# Altium schematic sheet Carte_Controle_Bras.SchDoc
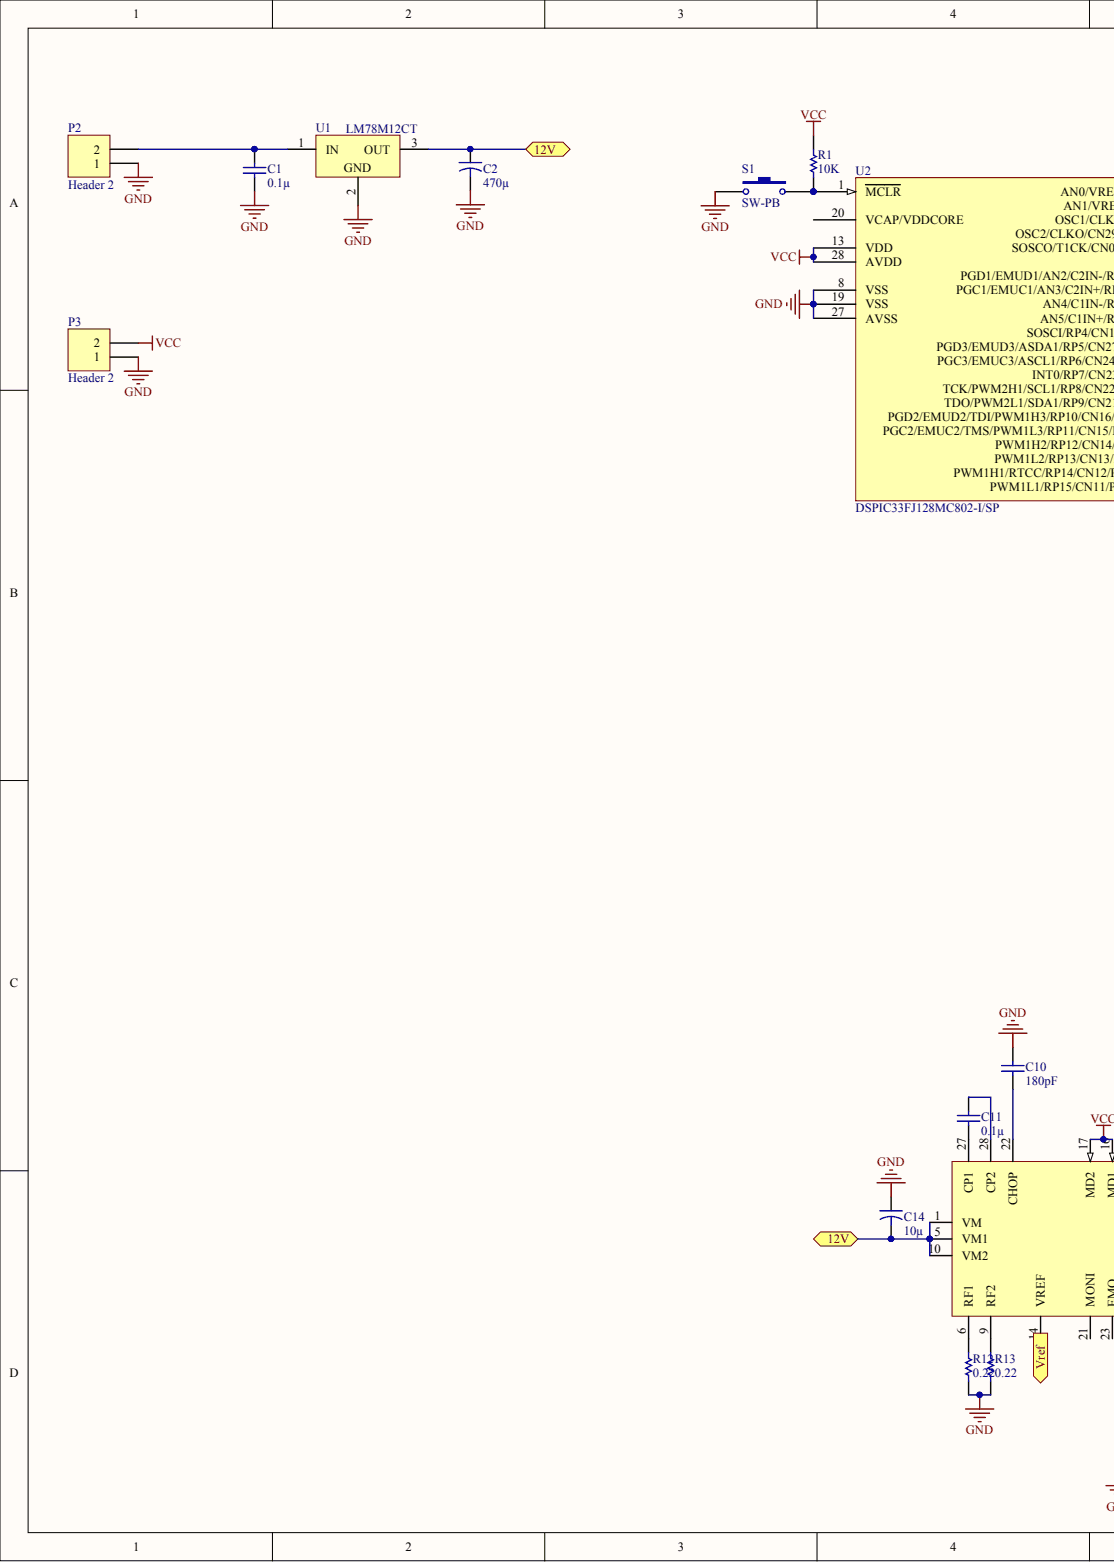
[diagram p0: Carte_Controle_Bras.SchDoc, left]
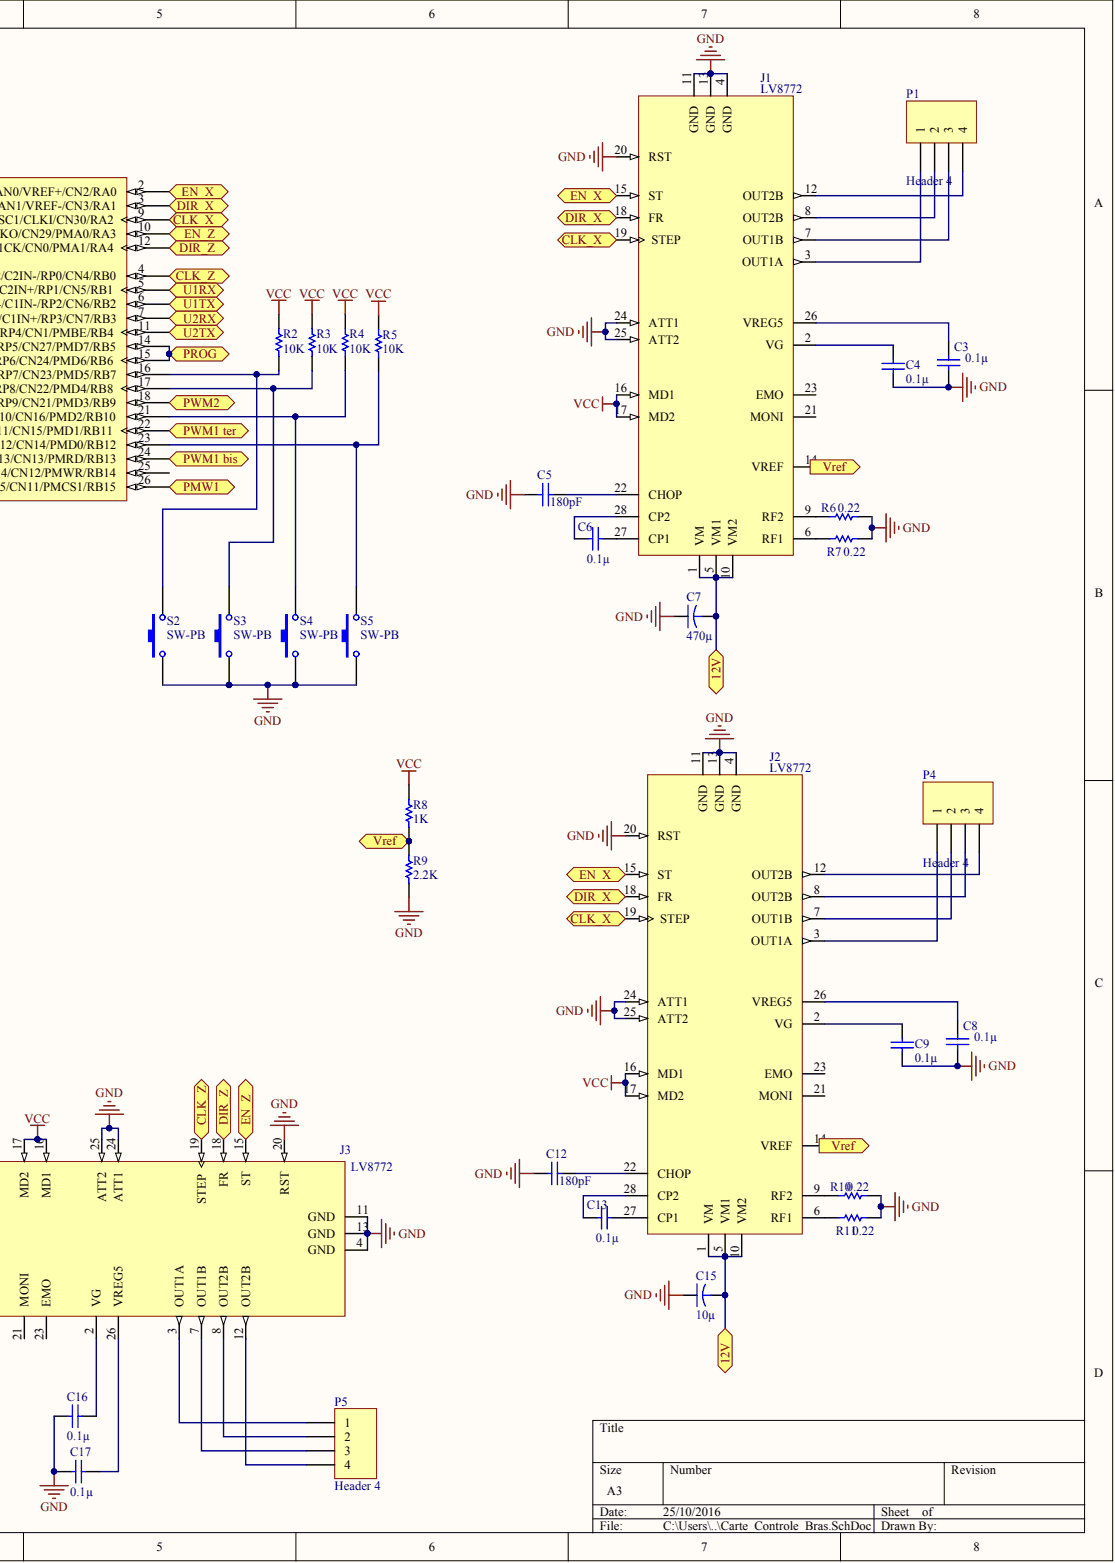
[diagram p1: Carte_Controle_Bras.SchDoc, right]

## Parts
C1  value 0.1µ  [RAD-0.3]  (hidden comment: Cap)
C2  value 470µ  [CAPR5-4X5]  (hidden comment: Cap2)
C3  value 0.1µ  [RAD-0.3]  (hidden comment: Cap)
C4  value 0.1µ  [RAD-0.3]  (hidden comment: Cap)
C5  value 180pF  [RAD-0.3]  (hidden comment: Cap)
C6  value 0.1µ  [RAD-0.3]  (hidden comment: Cap)
C7  value 470µ  [CAPR5-4X5]  (hidden comment: Cap2)
C8  value 0.1µ  [RAD-0.3]  (hidden comment: Cap)
C9  value 0.1µ  [RAD-0.3]  (hidden comment: Cap)
C10  value 180pF  [RAD-0.3]  (hidden comment: Cap)
C11  value 0.1µ  [RAD-0.3]  (hidden comment: Cap)
C12  value 180pF  [RAD-0.3]  (hidden comment: Cap)
C13  value 0.1µ  [RAD-0.3]  (hidden comment: Cap)
C14  value 10µ  [CAPR5-4X5]  (hidden comment: Cap2)
C15  value 10µ  [CAPR5-4X5]  (hidden comment: Cap2)
C16  value 0.1µ  [RAD-0.3]  (hidden comment: Cap)
C17  value 0.1µ  [RAD-0.3]  (hidden comment: Cap)
J1  LV8772
J2  LV8772
J3  LV8772
P1  Header 4  [HDR1X4]
P2  Header 2  [HDR1X2]
P3  Header 2  [HDR1X2]
P4  Header 4  [HDR1X4]
P5  Header 4  [HDR1X4]
R1  value 10K  [AXIAL-0.3]  (hidden comment: Res1)
R2  value 10K  [AXIAL-0.3]  (hidden comment: Res1)
R3  value 10K  [AXIAL-0.3]  (hidden comment: Res1)
R4  value 10K  [AXIAL-0.3]  (hidden comment: Res1)
R5  value 10K  [AXIAL-0.3]  (hidden comment: Res1)
R6  value 0.22  [AXIAL-0.3]  (hidden comment: Res1)
R7  value 0.22  [AXIAL-0.3]  (hidden comment: Res1)
R8  value 1K  [AXIAL-0.3]  (hidden comment: Res1)
R9  value 2.2K  [AXIAL-0.3]  (hidden comment: Res1)
R10  value 0.22  [AXIAL-0.3]  (hidden comment: Res1)
R11  value 0.22  [AXIAL-0.3]  (hidden comment: Res1)
R12  value 0.22  [AXIAL-0.3]  (hidden comment: Res1)
R13  value 0.22  [AXIAL-0.3]  (hidden comment: Res1)
S1  SW-PB  [SPST-2]
S2  SW-PB  [SPST-2]
S3  SW-PB  [SPST-2]
S4  SW-PB  [SPST-2]
S5  SW-PB  [SPST-2]
U1  LM78M12CT  [T03B]
U2  DSPIC33FJ128MC802-I/SP  [SPDIP300-SP28]

## Ports
12V, CLK_X, CLK_Z, DIR_X, DIR_Z, EN_X, EN_Z, PMW1, PROG, PWM1 BIS, PWM1 TER, PWM2, U1RX, U1TX, U2RX, U2TX, VREF

## Nets
GND: C1.1 C2.2 C3.2 C4.1 C5.2 C7.1 C8.2 C9.1 C10.2 C12.2 C14.1 C15.1 C16.1 C17.2 J1.4(GND) J1.11(GND) J1.13(GND) J1.20(RST) J1.24(ATT1) J1.25(ATT2) J2.4(GND) J2.11(GND) J2.13(GND) J2.20(RST) J2.24(ATT1) J2.25(ATT2) J3.4(GND) J3.11(GND) J3.13(GND) J3.20(RST) J3.24(ATT1) J3.25(ATT2) P2.1 P3.1 R6.1 R7.1 R9.2 R10.1 R11.1 R12.1 R13.1 S1.1 S2.1 S3.1 S4.1 S5.1 U1.2 U2.8(VSS) U2.19(VSS) U2.27(AVSS)
VCC: J1.16(MD1) J1.17(MD2) J2.16(MD1) J2.17(MD2) J3.16(MD1) J3.17(MD2) P3.2 R1.1 R2.1 R3.1 R4.1 R5.1 R8.1 U2.13(VDD) U2.28(AVDD)
12V: C2.1 C7.2 C14.2 C15.2 J1.1(VM) J1.5(VM1) J1.10(VM2) J2.1(VM) J2.5(VM1) J2.10(VM2) J3.1(VM) J3.5(VM1) J3.10(VM2) U1.3 ; port 12V
CLK_X: J1.19(STEP) J2.19(STEP) U2.9(OSC1/CLKI/CN30/RA2) ; port CLK_X
CLK_Z: J3.19(STEP) U2.4(PGD1/EMUD1/AN2/C2IN-/RP0/CN4/RB0) ; port CLK_Z
DIR_X: J1.18(FR) J2.18(FR) U2.3(AN1/VREF-/CN3/RA1) ; port DIR_X
DIR_Z: J3.18(FR) U2.12(SOSCO/T1CK/CN0/PMA1/RA4) ; port DIR_Z
EN_X: J1.15(ST) J2.15(ST) U2.2(AN0/VREF+/CN2/RA0) ; port EN_X
EN_Z: J3.15(ST) U2.10(OSC2/CLKO/CN29/PMA0/RA3) ; port EN_Z
PMW1: U2.26(PWM1L1/RP15/CN11/PMCS1/RB15) ; port PMW1
PROG: U2.14(PGD3/EMUD3/ASDA1/RP5/CN27/PMD7/RB5) U2.15(PGC3/EMUC3/ASCL1/RP6/CN24/PMD6/RB6) ; port PROG
PWM2: U2.18(TDO/PWM2L1/SDA1/RP9/CN21/PMD3/RB9) ; port PWM2
U1RX: U2.5(PGC1/EMUC1/AN3/C2IN+/RP1/CN5/RB1) ; port U1RX
U1TX: U2.6(AN4/C1IN-/RP2/CN6/RB2) ; port U1TX
U2RX: U2.7(AN5/C1IN+/RP3/CN7/RB3) ; port U2RX
U2TX: U2.11(SOSCI/RP4/CN1/PMBE/RB4) ; port U2TX
NetC1_2: C1.2 P2.2 U1.1
NetC3_1: C3.1 J1.26(VREG5)
NetC4_2: C4.2 J1.2(VG)
NetC5_1: C5.1 J1.22(CHOP)
NetC6_1: C6.1 J1.27(CP1)
NetC6_2: C6.2 J1.28(CP2)
NetC8_1: C8.1 J2.26(VREG5)
NetC9_2: C9.2 J2.2(VG)
NetC10_1: C10.1 J3.22(CHOP)
NetC11_1: C11.1 J3.27(CP1)
NetC11_2: C11.2 J3.28(CP2)
NetC12_1: C12.1 J2.22(CHOP)
NetC13_1: C13.1 J2.27(CP1)
NetC13_2: C13.2 J2.28(CP2)
NetC16_2: C16.2 J3.2(VG)
NetC17_1: C17.1 J3.26(VREG5)
NetJ1_3: J1.3(OUT1A) P1.1
NetJ1_6: J1.6(RF1) R7.2
NetJ1_7: J1.7(OUT1B) P1.3
NetJ1_8: J1.8(OUT2B) P1.2
NetJ1_9: J1.9(RF2) R6.2
NetJ1_12: J1.12(OUT2B) P1.4
NetJ1_14: J1.14(VREF) J2.14(VREF) J3.14(VREF) R8.2 R9.1 ; port VREF
NetJ2_3: J2.3(OUT1A) P4.1
NetJ2_6: J2.6(RF1) R11.2
NetJ2_7: J2.7(OUT1B) P4.2
NetJ2_8: J2.8(OUT2B) P4.3
NetJ2_9: J2.9(RF2) R10.2
NetJ2_12: J2.12(OUT2B) P4.4
NetJ3_3: J3.3(OUT1A) P5.1
NetJ3_6: J3.6(RF1) R12.2
NetJ3_7: J3.7(OUT1B) P5.3
NetJ3_8: J3.8(OUT2B) P5.2
NetJ3_9: J3.9(RF2) R13.2
NetJ3_12: J3.12(OUT2B) P5.4
NetR1_2: R1.2 S1.2 U2.1(MCLR)
NetR2_2: R2.2 S2.2 U2.16(INT0/RP7/CN23/PMD5/RB7)
NetR3_2: R3.2 S3.2 U2.17(TCK/PWM2H1/SCL1/RP8/CN22/PMD4/RB8)
NetR4_2: R4.2 S4.2 U2.21(PGD2/EMUD2/TDI/PWM1H3/RP10/CN16/PMD2/RB10)
NetR5_2: R5.2 S5.2 U2.23(PWM1H2/RP12/CN14/PMD0/RB12)
NetU2_22: U2.22(PGC2/EMUC2/TMS/PWM1L3/RP11/CN15/PMD1/RB11) ; port PWM1 TER
NetU2_24: U2.24(PWM1L2/RP13/CN13/PMRD/RB13) ; port PWM1 BIS

Not connected: J1 21(MONI) 23(EMO); J2 21(MONI) 23(EMO); J3 21(MONI) 23(EMO); U2 20(VCAP/VDDCORE) 25(PWM1H1/RTCC/RP14/CN12/PMWR/RB14)

## Library data of the parts
C1 C3-C6 C8-C13 C16 C17 = Cap: Capacitor; Component Kind: Standard; LatestRevisionDate: 17-Jul-2002; LatestRevisionNote: Re-released for DXP Platform.; Library Name: Miscellaneous Devices.IntLib; PackageReference: RAD-0.3; Published: 8-Jun-2000; Signal Integrity: Cap; Simulation: CAP; Publisher: Altium Limited
C2 C7 C14 C15 = Cap2: Capacitor; Component Kind: Standard; Library Name: Miscellaneous Devices.IntLib; PackageReference: CAPR5-4X5; Published: 23-Sep-2002; Signal Integrity: Cap; Simulation: CAP; Publisher: Altium Limited
P1 P4 P5 = Header 4: Header, 4-Pin; Component Kind: Standard; LatestRevisionDate: 17-Jul-2002; LatestRevisionNote: Re-released for DXP Platform.; Library Name: Miscellaneous Connectors.IntLib; Signal Integrity: Connector; Publisher: Altium Limited
P2 P3 = Header 2: Header, 2-Pin; Component Kind: Standard; LatestRevisionDate: 17-Jul-2002; LatestRevisionNote: Re-released for DXP Platform.; Library Name: Miscellaneous Connectors.IntLib; Signal Integrity: Connector; Publisher: Altium Limited
R1-R13 = Res1: Resistor; Component Kind: Standard; LatestRevisionDate: 17-Jul-2002; LatestRevisionNote: Re-released for DXP Platform.; Library Name: Miscellaneous Devices.IntLib; PackageReference: AXIAL-0.3; Published: 8-Jun-2000; Signal Integrity: Res; Simulation: RESISTOR; Publisher: Altium Limited
S1-S5 = SW-PB: Switch; Component Kind: Standard; LatestRevisionDate: 17-Jul-2002; LatestRevisionNote: Re-released for DXP Platform.; Library Name: Miscellaneous Devices.IntLib; PackageDescription: Switch; 2 Leads; PackageReference: SPST-2; Published: 24-Mar-1999; Publisher: Altium Limited
U1 = LM78M12CT: 3-Terminal Positive Voltage Regulators, 3-pin TO-220; Manufacturer: National Semiconductor; CompType: LDO IC; PackageTopMarking: NSUZXYTTE#*LM341T-12*LM78M12CT; Component Kind: Standard; ComponentLink1Description: LM78M12 Datasheet; ComponentLink1URL: http://www.national.com/ds/LM/LM78M12.pdf; ComponentLink2Description: LM78M12 Web Page; ComponentLink2URL: http://www.national.com/pf/LM/LM78M12.html; ComponentLink3Description: T03B Package Page; ComponentLink3URL: http://www.national.com/packaging/folders/t03b.html; DatasheetVersion: Aug-2005; Library Name: NSC Legacy.IntLib; PackageDescription: TO-220, Molded, 3 Lead, Straight; PackageReference: T03B; PackageVersion: revL, Jan-1992; PartNumber: LM78M12CT; PinCount: 3; Published: 4-Dec-2008; RoHS: O; Publisher: Altium Limited
U2 = DSPIC33FJ128MC802-I/SP: High-Performance 16-bit Digital Signal Controller, 128 KB Flash, 16 KB RAM, 28-Pin SPDIP, Industrial Temperature; Component Kind: Standard; ComponentLink1Description: Datasheet; ComponentLink1URL: http://ww1.microchip.com/downloads/en/DeviceDoc/70291D.pdf; ComponentLink2Description: Packaging Specification; ComponentLink2URL: http://www.microchip.com/stellent/groups/techpub_sg/documents/packagingspec/en012702.pdf; ComponentLink3Description: Microchip.com Home; ComponentLink3URL: http://www.microchip.com/; DatasheetVersion: revD, Nov-2009; FirstPublished: 29-Jan-2009; LatestRevisionDate: 02-Dec-2009; LatestRevisionNote: Updated to datasheet revision D, Nov-2009; Library Name: Microchip DSC 16-Bit dsPIC33F.IntLib; PackageDescription: 28-Lead Skinny Plastic Dual In-Line (SP) - 300 mil Body [SPDIP]; PackageReference: SPDIP300-SP28; PackageVersion: revBB, Aug-2009; Supplier 1: Digi-Key; Supplier 2: Farnell; Supplier 3: Newark; Supplier Part Number 1: DSPIC33FJ128MC802-I/SP-ND; Supplier Part Number 2: 1576808; Supplier Part Number 3: 39M8141; Publisher: Altium Limited
J1-J3 = LV8772: Component Kind: Standard; Library Name: lib_Gabriel.SchLib
